# Altium schematic sheet BBB_Connection.SchDoc
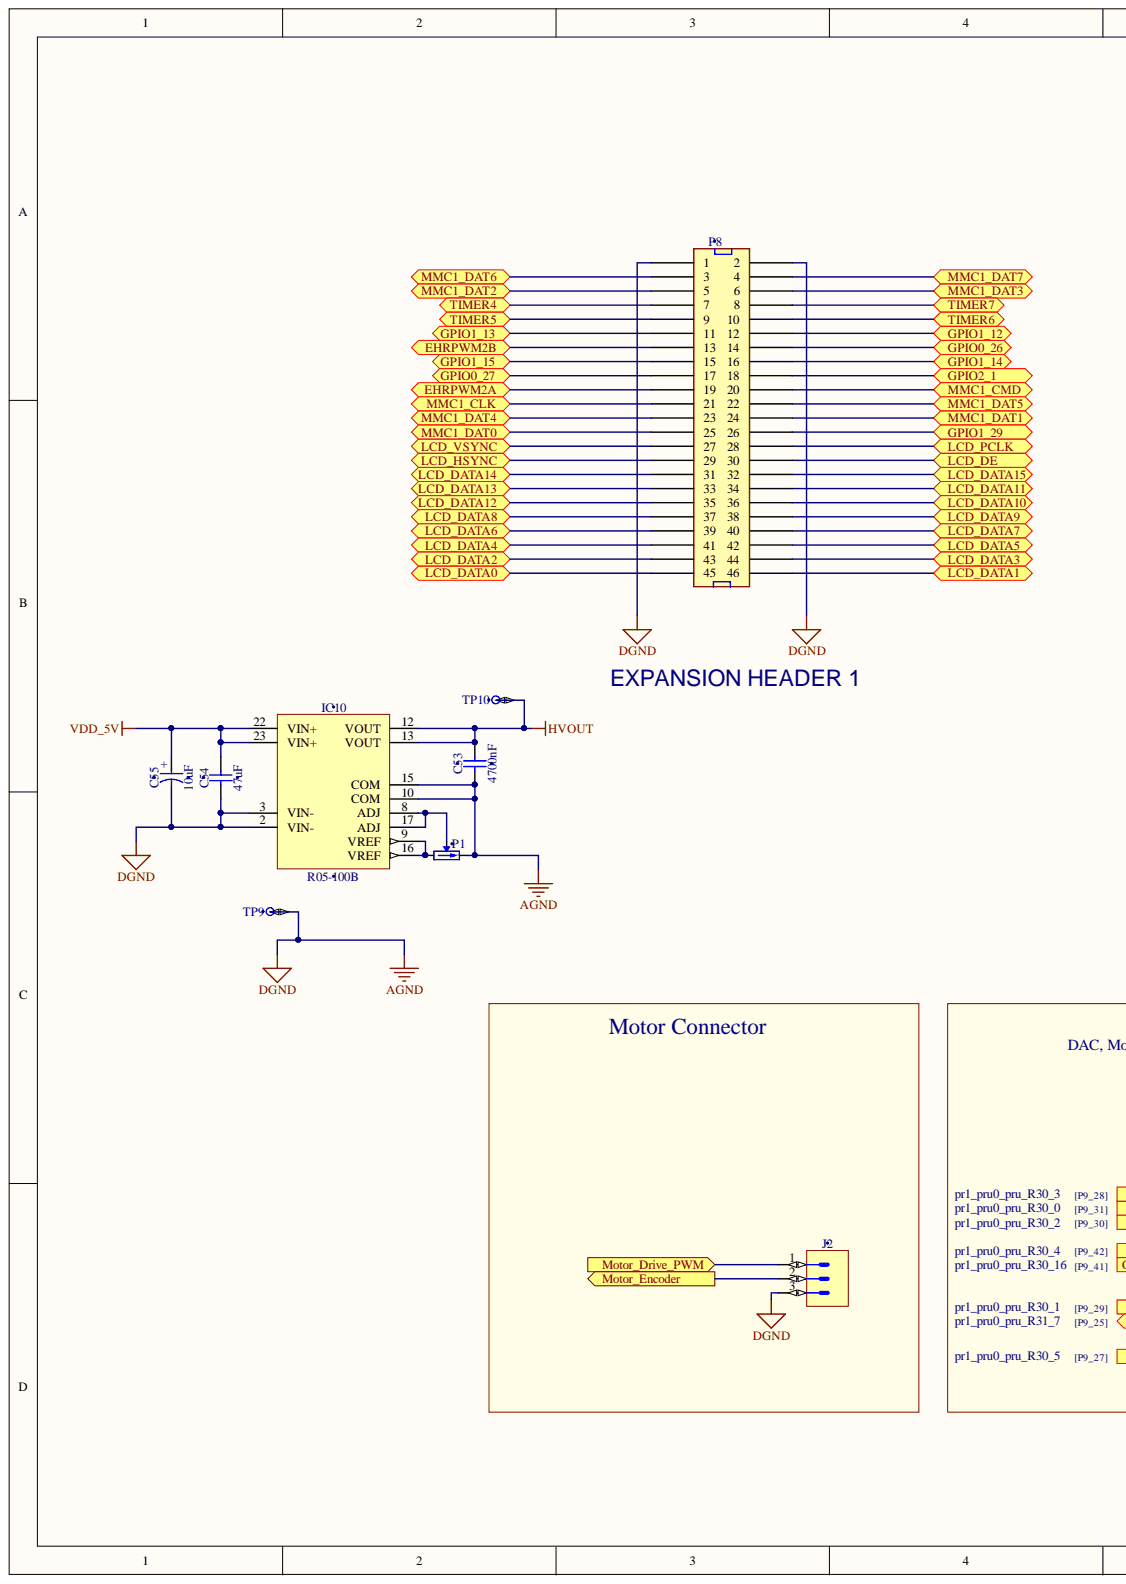
[diagram p0: BBB_Connection.SchDoc, left]
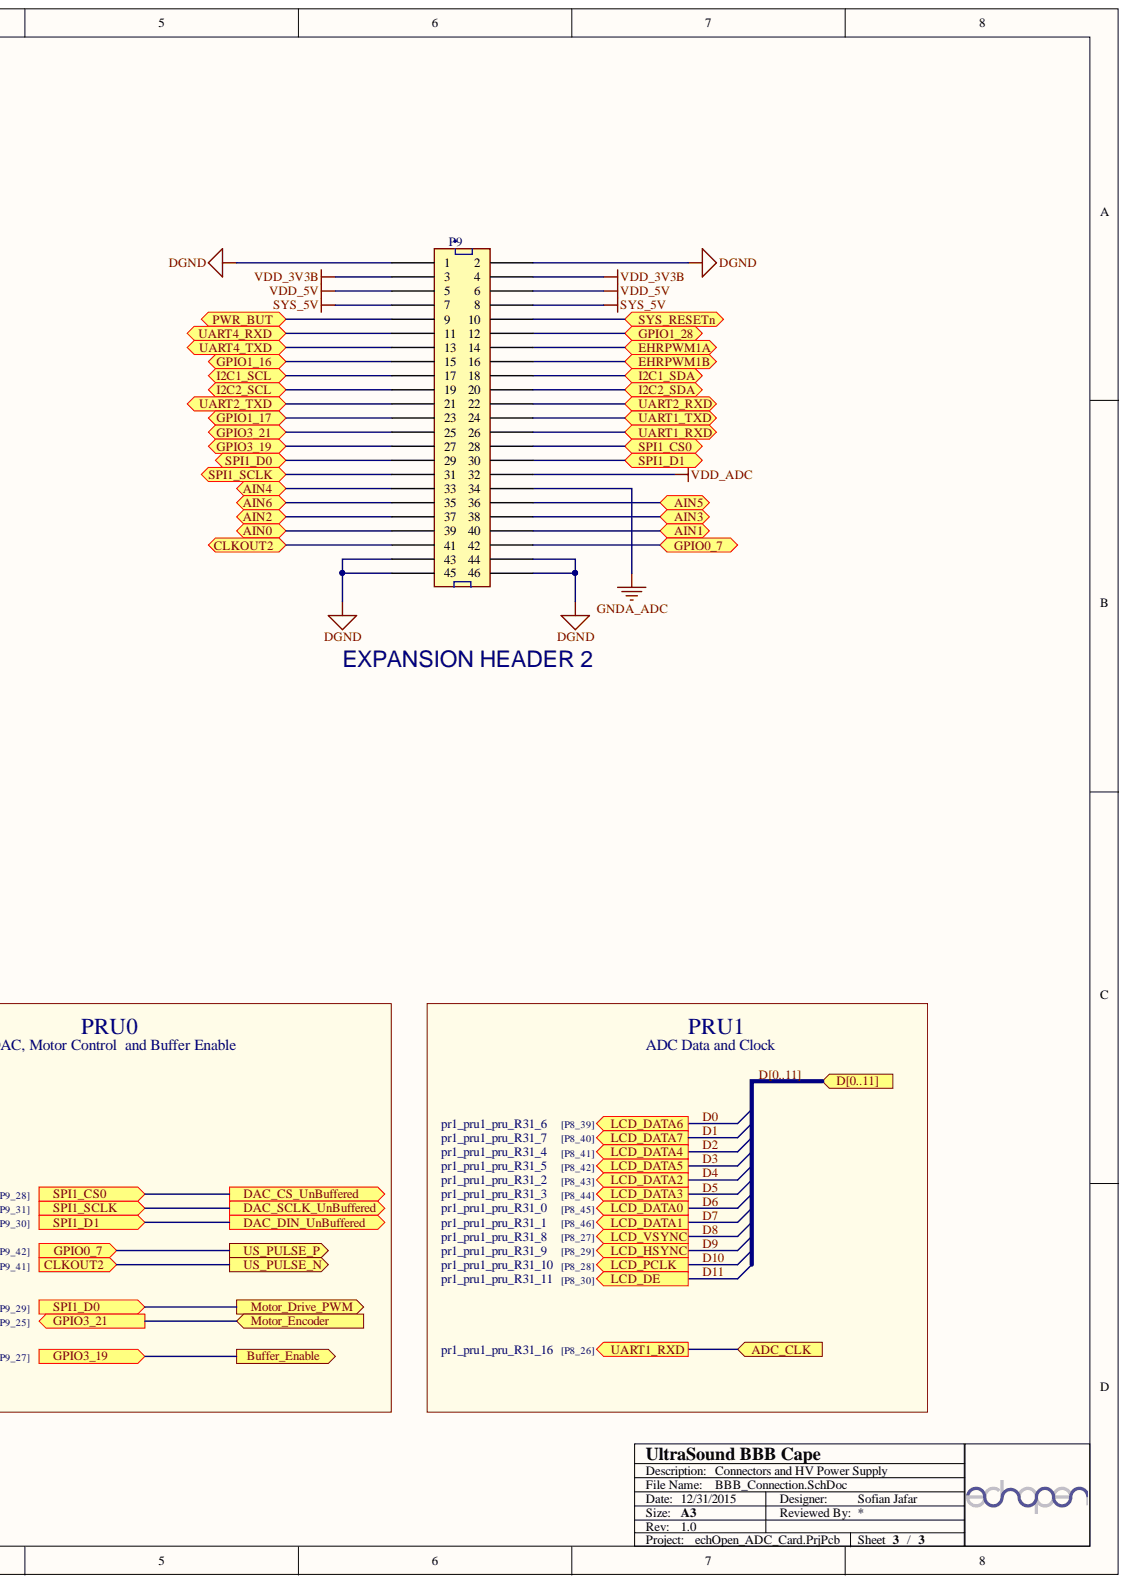
[diagram p1: BBB_Connection.SchDoc, right]

## Parts
C53  4700nF  [CAPC2012X110N]
C54  47uF  [CAPC3216X180N]
C55  10uF  [CAPMP3528X210N]
IC10  R05-100B  [PWS_RECOM_R05-100B]
J2  Family  [PHOENIX_1803439]  (value not drawn)
P1  5k  [TRIM_BOURNS_3223W]  (value not drawn)
P8  Familly  [SAMTEC_TSW-123-07-L-D-LL]  (value not drawn)
P9  Familly  [SAMTEC_TSW-123-07-L-D-LL]  (value not drawn)
TP9  Test Point  [NICOMATIC_C12000B]  (value not drawn)
TP10  Test Point  [NICOMATIC_C12000B]  (value not drawn)

## Ports
ADC_CLK, AIN0, AIN1, AIN2, AIN3, AIN4, AIN5, AIN6, BUFFER_ENABLE, CLKOUT2, D0, D1, D2, D3, D4, D5, D6, D7, D8, D9, D10, D11, D[0..11], DAC_CS_UNBUFFERED, DAC_DIN_UNBUFFERED, DAC_SCLK_UNBUFFERED, EHRPWM1A, EHRPWM1B, EHRPWM2A, EHRPWM2B, GPIO0_7, GPIO0_26, GPIO0_27, GPIO1_12, GPIO1_13, GPIO1_14, GPIO1_15, GPIO1_16, GPIO1_17, GPIO1_28, GPIO1_29, GPIO2_1, GPIO3_19, GPIO3_21, I2C1_SCL, I2C1_SDA, I2C2_SCL, I2C2_SDA, LCD_DATA0, LCD_DATA1, LCD_DATA2, LCD_DATA3, LCD_DATA4, LCD_DATA5, LCD_DATA6, LCD_DATA7, LCD_DATA8, LCD_DATA9, LCD_DATA10, LCD_DATA11, LCD_DATA12, LCD_DATA13, LCD_DATA14, LCD_DATA15, LCD_DE, LCD_HSYNC, LCD_PCLK, LCD_VSYNC, MMC1_CLK, MMC1_CMD, MMC1_DAT0, MMC1_DAT1, MMC1_DAT2, MMC1_DAT3, MMC1_DAT4, MMC1_DAT5, MMC1_DAT6, MMC1_DAT7, MOTOR_DRIVE_PWM, MOTOR_ENCODER, PWR_BUT, SPI1_CS0, SPI1_D0, SPI1_D1, SPI1_SCLK, SYS_RESETN, TIMER4, TIMER5, TIMER6, TIMER7, UART1_RXD, UART1_TXD, UART2_RXD, UART2_TXD, UART4_RXD, UART4_TXD, US_PULSE_N, US_PULSE_P

## Nets
AGND: C53.1 C54.1 C55.2 IC10.2(VIN-) IC10.3(VIN-) IC10.10(COM) IC10.15(COM) J2.3 P1.3 P8.1 P8.2 P9.1 P9.2 P9.43 P9.44 P9.45 P9.46 TP9.1
GNDA_ADC: P9.34
HVOUT: C53.2 IC10.12(VOUT) IC10.13(VOUT) TP10.1
SYS_5V: P9.7 P9.8
VDD_3V3B: P9.3 P9.4
VDD_5V: C54.2 C55.1 IC10.22(VIN+) IC10.23(VIN+) P9.5 P9.6
VDD_ADC: P9.32
ADC_CLK: P9.26 ; port ADC_CLK, port UART1_RXD
AIN0: P9.39 ; port AIN0
AIN1: P9.40 ; port AIN1
AIN2: P9.37 ; port AIN2
AIN3: P9.38 ; port AIN3
AIN4: P9.33 ; port AIN4
AIN5: P9.36 ; port AIN5
AIN6: P9.35 ; port AIN6
CLKOUT2: P9.41 ; port CLKOUT2, port US_PULSE_N
D0: P8.39 ; port D[0..11], port LCD_DATA6  [also labelled D]
D1: P8.40 ; port D[0..11], port LCD_DATA7  [also labelled D]
D2: P8.41 ; port D[0..11], port LCD_DATA4  [also labelled D]
D3: P8.42 ; port D[0..11], port LCD_DATA5  [also labelled D]
D4: P8.43 ; port D[0..11], port LCD_DATA2  [also labelled D]
D5: P8.44 ; port D[0..11], port LCD_DATA3  [also labelled D]
D6: P8.45 ; port D[0..11], port LCD_DATA0  [also labelled D]
D7: P8.46 ; port D[0..11], port LCD_DATA1  [also labelled D]
D8: P8.27 ; port D[0..11], port LCD_VSYNC  [also labelled D]
D9: P8.29 ; port D[0..11], port LCD_HSYNC  [also labelled D]
D10: P8.28 ; port D[0..11], port LCD_PCLK  [also labelled D]
D11: P8.30 ; port D[0..11], port LCD_DE  [also labelled D]
EHRPWM1A: P9.14 ; port EHRPWM1A
EHRPWM1B: P9.16 ; port EHRPWM1B
EHRPWM2A: P8.19 ; port EHRPWM2A
EHRPWM2B: P8.13 ; port EHRPWM2B
GPIO0_7: P9.42 ; port GPIO0_7, port US_PULSE_P
GPIO0_26: P8.14 ; port GPIO0_26
GPIO0_27: P8.17 ; port GPIO0_27
GPIO1_12: P8.12 ; port GPIO1_12
GPIO1_13: P8.11 ; port GPIO1_13
GPIO1_14: P8.16 ; port GPIO1_14
GPIO1_15: P8.15 ; port GPIO1_15
GPIO1_16: P9.15 ; port GPIO1_16
GPIO1_17: P9.23 ; port GPIO1_17
GPIO1_28: P9.12 ; port GPIO1_28
GPIO1_29: P8.26 ; port GPIO1_29
GPIO2_1: P8.18 ; port GPIO2_1
GPIO3_19: P9.27 ; port GPIO3_19
GPIO3_21: J2.2 P9.25 ; port GPIO3_21
I2C1_SCL: P9.17 ; port I2C1_SCL
I2C1_SDA: P9.18 ; port I2C1_SDA
I2C2_SCL: P9.19 ; port I2C2_SCL
I2C2_SDA: P9.20 ; port I2C2_SDA
LCD_DATA8: P8.37 ; port LCD_DATA8
LCD_DATA9: P8.38 ; port LCD_DATA9
LCD_DATA10: P8.36 ; port LCD_DATA10
LCD_DATA11: P8.34 ; port LCD_DATA11
LCD_DATA12: P8.35 ; port LCD_DATA12
LCD_DATA13: P8.33 ; port LCD_DATA13
LCD_DATA14: P8.31 ; port LCD_DATA14
LCD_DATA15: P8.32 ; port LCD_DATA15
MMC1_CLK: P8.21 ; port MMC1_CLK
MMC1_CMD: P8.20 ; port MMC1_CMD
MMC1_DAT0: P8.25 ; port MMC1_DAT0
MMC1_DAT1: P8.24 ; port MMC1_DAT1
MMC1_DAT2: P8.5 ; port MMC1_DAT2
MMC1_DAT3: P8.6 ; port MMC1_DAT3
MMC1_DAT4: P8.23 ; port MMC1_DAT4
MMC1_DAT5: P8.22 ; port MMC1_DAT5
MMC1_DAT6: P8.3 ; port MMC1_DAT6
MMC1_DAT7: P8.4 ; port MMC1_DAT7
PWR_BUT: P9.9 ; port PWR_BUT
SPI1_CS0: P9.28 ; port SPI1_CS0
SPI1_D0: J2.1 P9.29 ; port SPI1_D0
SPI1_D1: P9.30 ; port SPI1_D1
SPI1_SCLK: P9.31 ; port SPI1_SCLK
TIMER4: P8.7 ; port TIMER4
TIMER5: P8.9 ; port TIMER5
TIMER6: P8.10 ; port TIMER6
TIMER7: P8.8 ; port TIMER7
UART1_TXD: P9.24 ; port UART1_TXD
UART2_RXD: P9.22 ; port UART2_RXD
UART2_TXD: P9.21 ; port UART2_TXD
UART4_RXD: P9.11 ; port UART4_RXD
UART4_TXD: P9.13 ; port UART4_TXD
NetIC10_8: IC10.8(ADJ) IC10.17(ADJ) P1.2
NetIC10_9: IC10.9(VREF) IC10.16(VREF) P1.1
NetP9_10: P9.10 ; port SYS_RESETN

## Library data of the parts
C53 = CC0805_4700NF_250V_10%_X7R: Non-polarised capacitor; Manufacturer: GENERIC; Manufacturer1 Part Number: GRM21AR72E472KW01D; Part Description: SMD Multilayer Chip Ceramic Capacitor; Database Table Name: Capacitors SMD; TC: X7R; Tolerance: ±10%; Voltage: 250V; Case: 0805; Component Kind: Standard; Component Type: Standard; CreateDate: 12/21/2015; LatestRevisionDate: 12/21/2015; Manufacturer1 ComponentHeight: 1.1mm; Manufacturer1 Example: MURATE; Manufacturer Part Number: CC0805_4700NF_250V_10%_X7R; Mounted: Yes; Part Number: CC0805_4700NF_250V_10%_X7R; Pin Count: 2; SMD: Yes; Socket: No; Status: Preferred; Table: Capacitors SMD; Value: 4700nF; Author: S. Jafar; Library Name: Sof-Capacitors.DbLib
C54 = CC1206_47UF_25V_20%_X5R: Non-polarised capacitor; Manufacturer: TDK; Manufacturer Part Number: C3216X5R1E476M160AC; Part Description: SMD Multilayer Chip Ceramic Capacitor; Database Table Name: Capacitors SMD; TC: X5R; Tolerance: ±20%; Voltage: 25V; Case: 1206; ComponentHeight: 1.8mm; Component Kind: Standard; Component Type: Standard; CreateDate: 11/20/2013; HelpURL: \\cern.ch\dfs\Applications\Altium\Datasheets\CC1206_X5R_TDK_C.pdf; LatestRevisionDate: 11/20/2013; Mounted: Yes; Part Number: CC1206_47UF_25V_20%_X5R; Pin Count: 2; SMD: Yes; Socket: No; Status: None; Table: Capacitors SMD; Value: 47uF; Author: CERN DEM JLC; Library Name: Sof-Capacitors.DbLib
C55 = CTE3528-21_10UF_16V_10%_LESR0800: Polarised capacitor; Manufacturer: GENERIC; Manufacturer1 Part Number: TPSB106K016R0800; Part Description: Low ESR 0R8 Solid Tantalum Chip Capacitor; Database Table Name: Capacitors SMD; Tolerance: ±10%; Voltage: 16V; Case: B; Component Kind: Standard; Component Type: Standard; CreateDate: 5/5/2008; HelpURL: \\cern.ch\dfs\Applications\Altium\Datasheets\CTE-LESR_AVX_TPS.pdf; LatestRevisionDate: 1/20/2009; Manufacturer1 ComponentHeight: 2.1mm; Manufacturer1 Example: AVX; Manufacturer Part Number: CTE3528-21_10UF_16V_10%_LESR0800; Mounted: Yes; Part Number: CTE3528-21_10UF_16V_10%_LESR0800; Pin Count: 2; SMD: Yes; Socket: No; Status: Preferred; Table: Capacitors SMD; Value: 10uF; Author: CERN DEM JLC; Library Name: Sof-Capacitors.DbLib
IC10 = R05-100B: Power Supply; Manufacturer: RECOM POWER; Part Description: +5VDC to +55-135VDC 5W Power Supply; Database Table Name: Power Supplies; ComponentHeight: 9.4mm; Component Kind: Standard; Component Type: Standard; CreateDate: 12/21/2015; Device: R05-100B; LatestRevisionDate: 12/21/2015; Manufacturer Part Number: R05-100B; Mounted: Yes; PackageDescription: 55-135V 5W Power Supply, Body 32x21mm; Part Number: R05-100B; Pin Count: 12; SMD: No; Socket: No; Status: None; Table: Power Supplies; Author: S. Jafar; Library Name: Sof-ICs And Semiconductors.DbLib
J2 = PHOENIX_1803439: Connector 3 Male; Manufacturer: PHOENIX CONTACT; Manufacturer Part Number: 1803439 (MCV 1,5/3-G-3,81); Part Description: 8A 160 V, Pitch: 3.81mm, 3 Positions Header; ComponentHeight: 9.2mm; Component Kind: Standard; Component Type: Standard; CreateDate: 5/4/2010; Database Table Name: PHOENIX; HelpURL: \\cern.ch\dfs\Applications\Altium\Datasheets\PHOENIX_1803439.pdf; LatestRevisionDate: 5/4/2010; Mounted: Yes; Part Number: PHOENIX_1803439; Pin Count: 3; SMD: No; Socket: No; Status: None; Table: PHOENIX; Author: CERN DEM JLC; Library Name: Sof-Connectors.DbLib
P1 = TRIM_11T_5K_BOURNS_3223W-1-502E: Trimming Potentiometer; Manufacturer: BOURNS; Part Description: 11 Turns SMD Trimpot® Trimming Potentiometer (Top Adjust); Database Table Name: Potentiometers SMD; Power: 0.125W; TC: ±100ppm/°C; Tolerance: ±20%; Case: 3223W; ComponentHeight: 4.16mm; Component Kind: Standard; Component Type: Standard; CreateDate: 3/31/2011; HelpURL: \\cern.ch\dfs\Applications\Altium\Datasheets\TRIM_BOURNS_3223W.pdf; LatestRevisionDate: 12/18/2012; Manufacturer Part Number: 3223W-1-502E; Mounted: Yes; Part Number: TRIM_11T_5K_BOURNS_3223W-1-502E; Pin Count: 3; SMD: Yes; Socket: No; Table: Potentiometers SMD; Value: 5k; Author: CERN DEM JLC; Library Name: Sof-Resistors.DbLib
P8 P9 = SAMTEC_TSW-123-07-L-D-LL_a: Connector 46 Male; Part Description: 46 Contacts - Pitch 2.54mm - Dual Row Through Mount  Straigh Header With Locking Lead (Lead Length 2.54mm); Database Table Name: SAMTEC; ComponentHeight: 8.38mm; Component Kind: Standard; Component Type: Standard; CreateDate: 12/26/2015; Family: Header 46; HelpURL: \\cern.ch\dfs\Applications\Altium\Datasheets\SAMTEC_TSW-123-07-L-D-LL.pdf; LatestRevisionDate: 12/26/2015; Manufacturer: SAMTEC; Manufacturer Part Number: TSW-123-07-L-D-LL; Mounted: Yes; Part Number: SAMTEC_TSW-123-07-L-D-LL_a; Pin Count: 46; SMD: No; Socket: No; Status: None; Table: SAMTEC; Author: S. Jafar; Library Name: Sof-Connectors.DbLib
TP9 TP10 = NICOMATIC_C12000B: Part Description: SMD Test Point; Database Table Name: Miscellaneous; ComponentHeight: 1.5mm; Component Kind: Standard; Component Type: Standard; CreateDate: 3/25/2009; Description: Test Point; Family: Test Point; HelpURL: \\cern.ch\dfs\Applications\Altium\Datasheets\NICOMATIC_C12000B.pdf; LatestRevisionDate: 3/25/2009; Manufacturer: NICOMATIC; Manufacturer Part Number: C12000B; Mounted: Yes; Part Number: NICOMATIC_C12000B; Pin Count: 1; SMD: Yes; Socket: No; Status: None; Table: Miscellaneous; Author: CERN DEM JLC; Library Name: Sof-Connectors.DbLib
